# Altium schematic sheet AuxPowerSupply.SchDoc(AuxPowerSupply)
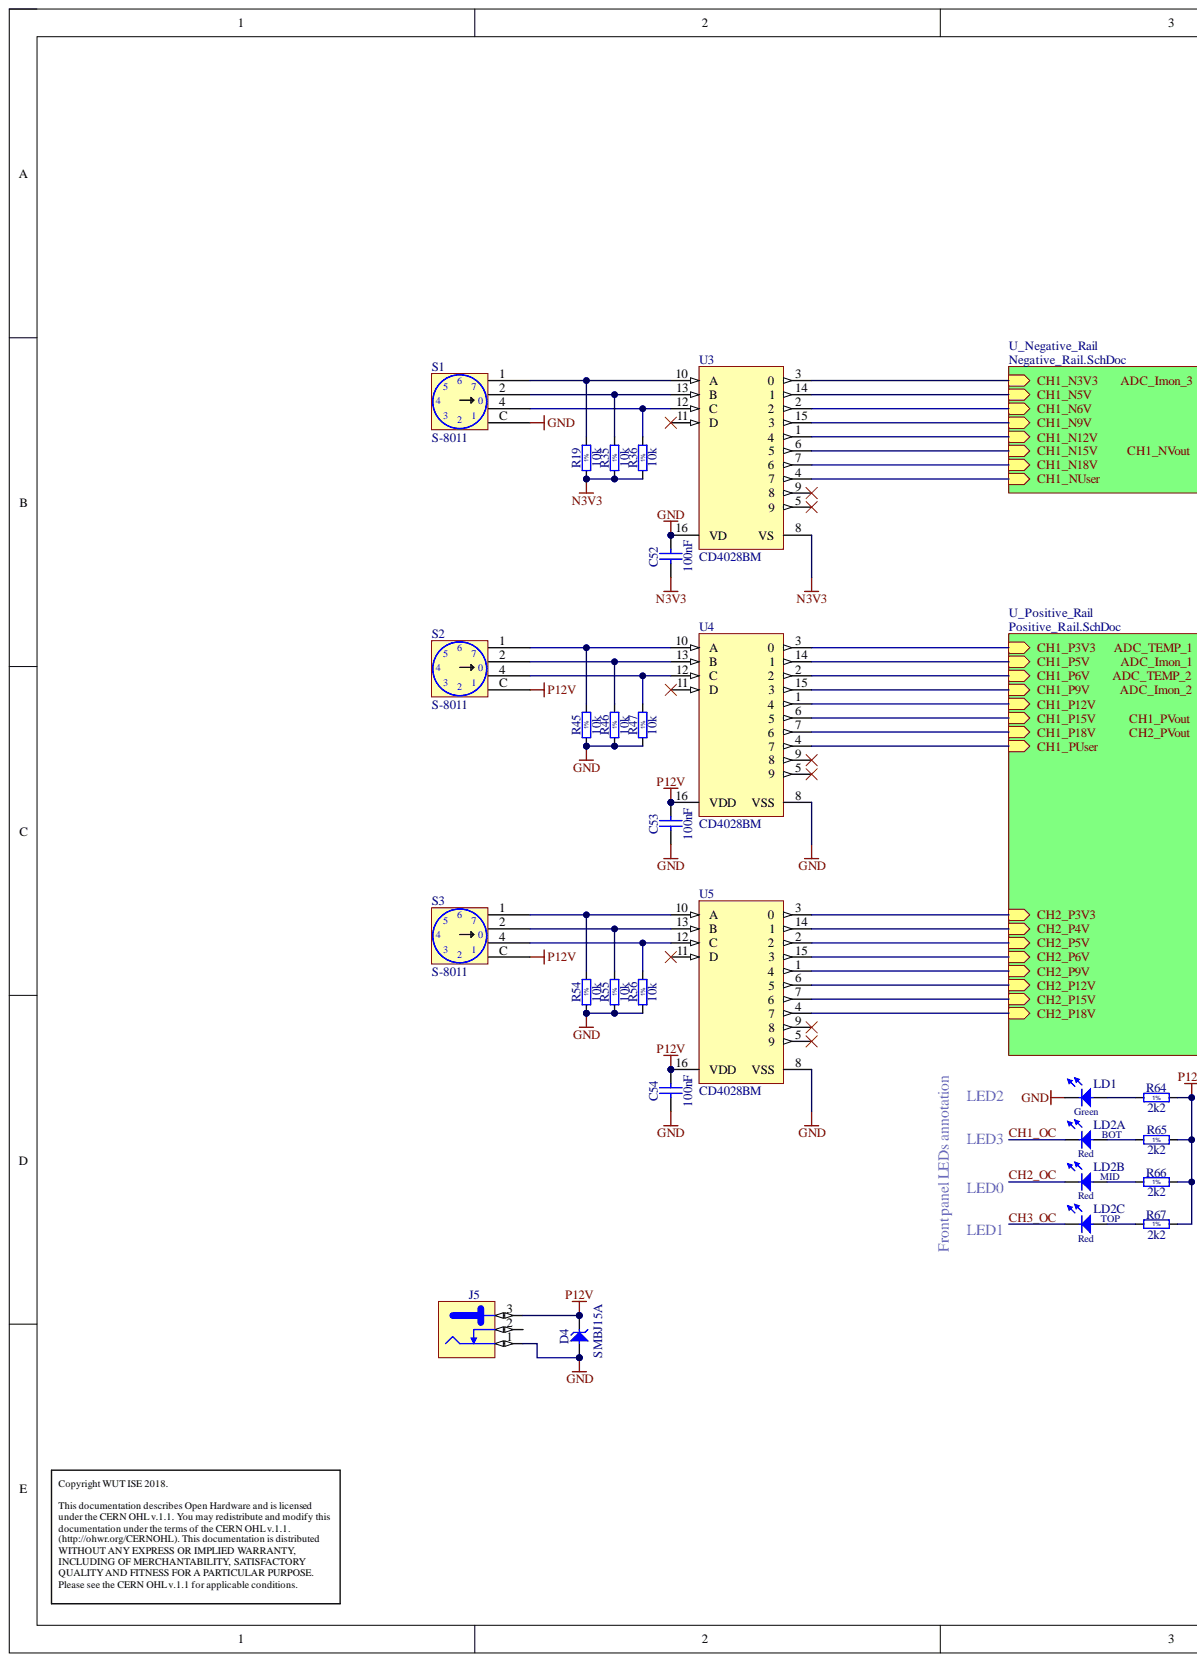
[diagram p0: AuxPowerSupply.SchDoc, left]
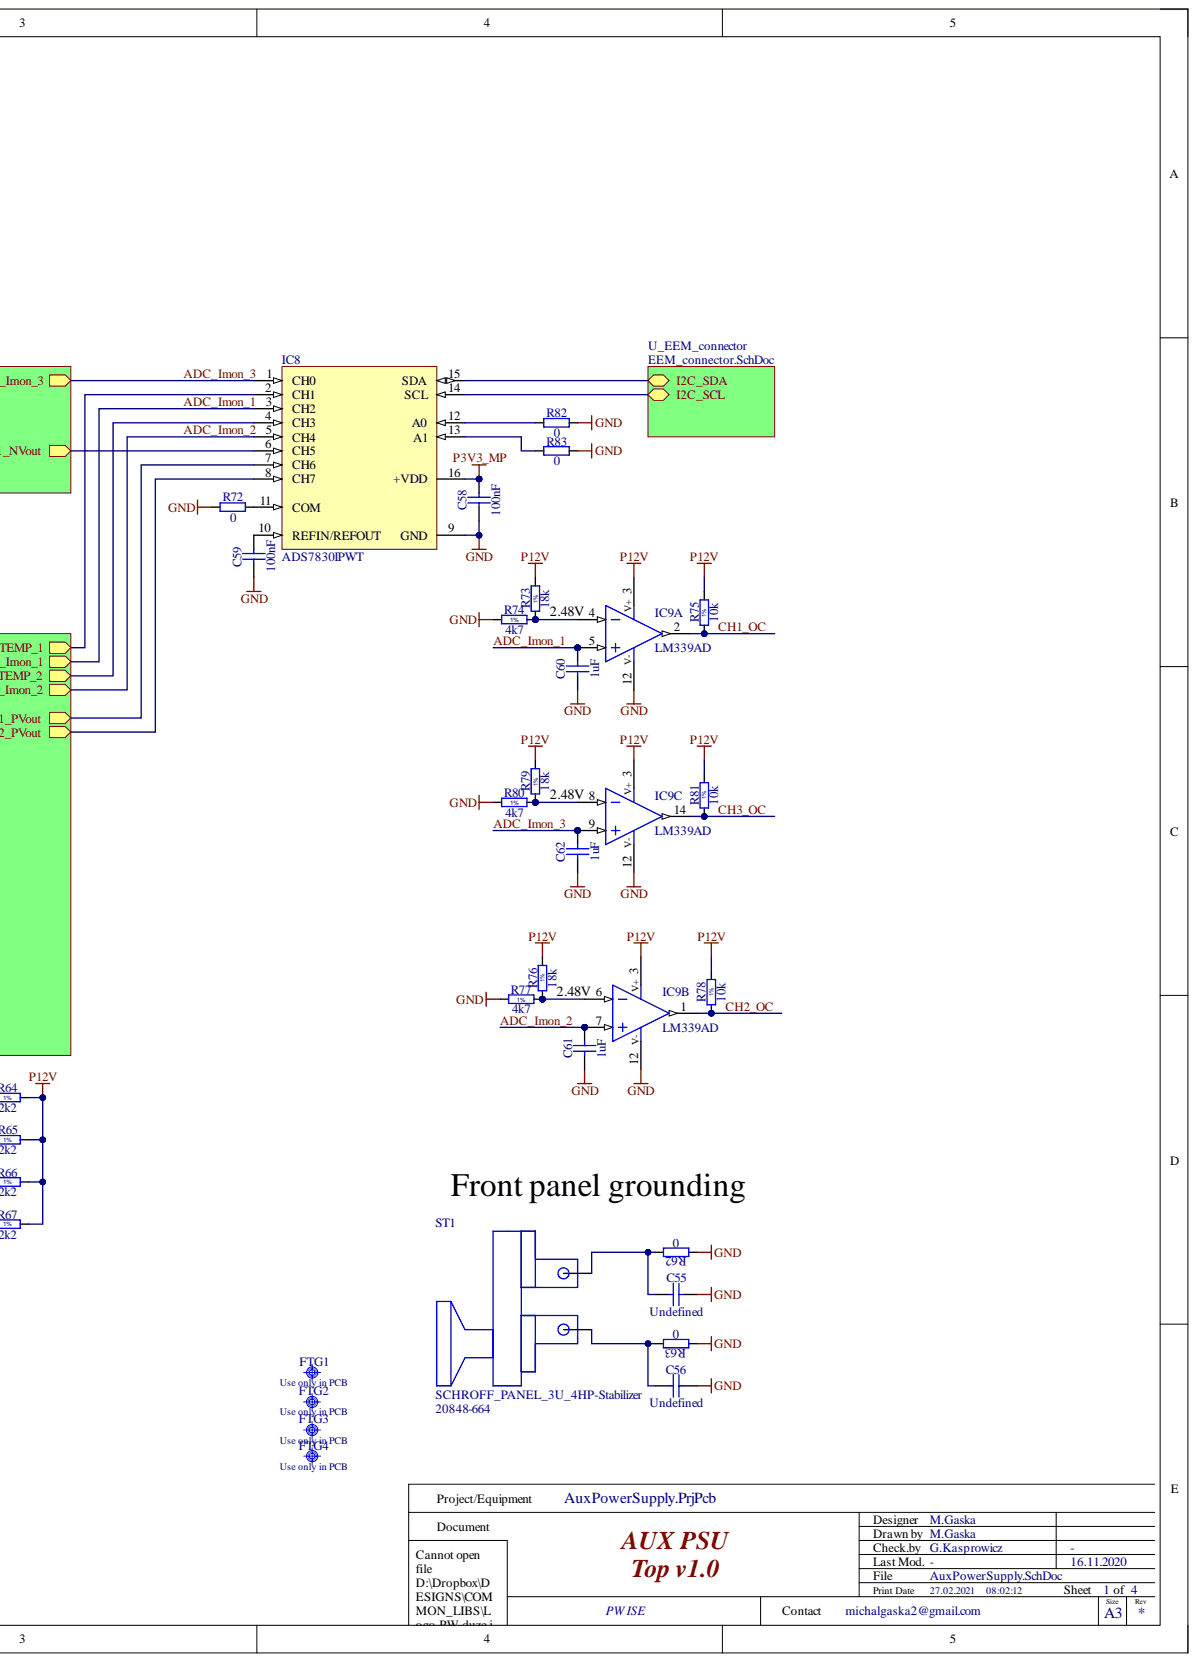
[diagram p1: AuxPowerSupply.SchDoc, right]

## Parts
C52  100nF  [CAPC1608X87N]
C53  100nF  [CAPC1608X87N]
C54  100nF  [CAPC1608X87N]
C55  Undefined  [CAPC2012X135N]
C56  Undefined  [CAPC2012X135N]
C58  100nF  [CAPC1608X87N]
C59  100nF  [CAPC1608X87N]
C60  1uF  [CAPC1608X87N]
C61  1uF  [CAPC1608X87N]
C62  1uF  [CAPC1608X87N]
D4  SMBJ15A  [DIOM5336X265N]
FTG1
FTG2
FTG3
FTG4
IC8  ADS7830IPWT  [SOP65P640X120-16N]
IC9  LM339AD  [SOIC127P600X175-14N]  (parts A,B,C)
J5  Jack  [SWITCHCRAFT_712RA]  (value not drawn)
LD1  Green  [LED_DIALIGHT_551-0207F]
LD2  Red/Red/Red  [LED_DIALIGHT_564-0100-111F]  (parts A,B,C)
R19  10k  [RESC1005X40N]
R35  10k  [RESC1005X40N]
R36  10k  [RESC1005X40N]
R45  10k  [RESC1005X40N]
R46  10k  [RESC1005X40N]
R47  10k  [RESC1005X40N]
R54  10k  [RESC1005X40N]
R55  10k  [RESC1005X40N]
R56  10k  [RESC1005X40N]
R62  0  [RESC1608X55N]
R63  0  [RESC1608X55N]
R64  2k2  [RESC1005X40N]
R65  2k2  [RESC1005X40N]
R66  2k2  [RESC1005X40N]
R67  2k2  [RESC1005X40N]
R72  0  [RESC1005X40N]
R73  18k  [RESC1005X40N]
R74  4k7  [RESC1005X40N]
R75  10k  [RESC1005X40N]
R76  18k  [RESC1005X40N]
R77  4k7  [RESC1005X40N]
R78  10k  [RESC1005X40N]
R79  18k  [RESC1005X40N]
R80  4k7  [RESC1005X40N]
R81  10k  [RESC1005X40N]
R82  0  [RESC1005X40N]
R83  0  [RESC1005X40N]
S1  S-8011  [S-8011]
S2  S-8011  [S-8011]
S3  S-8011  [S-8011]
ST1  SCHROFF_PANEL_3U_4HP-Stabilizer  Manufacturer Part Number 2: 20848-664  [SCHROFF_PANEL_3HP_4U - Stabilizer]
U3  CD4028BM  [D0016A_N]
U4  CD4028BM  [D0016A_N]
U5  CD4028BM  [D0016A_N]

## Nets
GND: C52.2 C53.1 C54.1 C55.2 C56.2 C58.1 C59.1 C60.1 C61.1 C62.1 D4.2 IC8.9(GND) IC9.12(V-) J5.1 LD1.1 R45.1 R46.1 R47.1 R54.1 R55.1 R56.1 R62.1 R63.1 R72.1 R74.1 R77.1 R80.1 R82.2 R83.2 S1.C U3.16(VD) U4.8(VSS) U5.8(VSS)
N3V3: C52.1 R19.1 R35.1 R36.1 U3.8(VS)
P3V3_MP: C58.2 IC8.16(+VDD)
P12V: C53.2 C54.2 D4.1 IC9.3 J5.3 R64.1 R65.1 R66.1 R67.1 R73.2 R75.2 R76.2 R78.2 R79.2 R81.2 S2.C S3.C U4.16(VDD) U5.16(VDD)
ADC_Imon_1: C60.2 IC8.3(CH2) IC9.5
ADC_Imon_2: C61.2 IC8.5(CH4) IC9.7
ADC_Imon_3: C62.2 IC8.1(CH0) IC9.9
CH1_OC: IC9.2 LD2.1 R75.1
CH2_OC: IC9.1 LD2.3 R78.1
CH3_OC: IC9.14 LD2.5 R81.1
NetC55_1: C55.1 R62.2 ST1.1
NetC56_1: C56.1 R63.2 ST1.0
NetC59_2: C59.2 IC8.10(REFIN/REFOUT)
NetIC8_2: IC8.2(CH1)
NetIC8_4: IC8.4(CH3)
NetIC8_6: IC8.6(CH5)
NetIC8_7: IC8.7(CH6)
NetIC8_8: IC8.8(CH7)
NetIC8_11: IC8.11(COM) R72.2
NetIC8_12: IC8.12(A0) R82.1
NetIC8_13: IC8.13(A1) R83.1
NetIC8_14: IC8.14(SCL)
NetIC8_15: IC8.15(SDA)
NetIC9_4: IC9.4 R73.1 R74.2
NetIC9_6: IC9.6 R76.1 R77.2
NetIC9_8: IC9.8 R79.1 R80.2
NetJ5_2: J5.2
NetLD1_2: LD1.2 R64.2
NetLD2_2: LD2.2 R65.2
NetLD2_4: LD2.4 R66.2
NetLD2_6: LD2.6 R67.2
NetR19_2: R19.2 S1.1 U3.10(A)
NetR35_2: R35.2 S1.2 U3.13(B)
NetR36_2: R36.2 S1.4 U3.12(C)
NetR45_2: R45.2 S2.1 U4.10(A)
NetR46_2: R46.2 S2.2 U4.13(B)
NetR47_2: R47.2 S2.4 U4.12(C)
NetR54_2: R54.2 S3.1 U5.10(A)
NetR55_2: R55.2 S3.2 U5.13(B)
NetR56_2: R56.2 S3.4 U5.12(C)
NetU3_1: U3.1
NetU3_2: U3.2
NetU3_3: U3.3
NetU3_4: U3.4
NetU3_5: U3.5
NetU3_6: U3.6
NetU3_7: U3.7
NetU3_9: U3.9
NetU3_11: U3.11(D)
NetU3_14: U3.14
NetU3_15: U3.15
NetU4_1: U4.1
NetU4_2: U4.2
NetU4_3: U4.3
NetU4_4: U4.4
NetU4_5: U4.5
NetU4_6: U4.6
NetU4_7: U4.7
NetU4_9: U4.9
NetU4_11: U4.11(D)
NetU4_14: U4.14
NetU4_15: U4.15
NetU5_1: U5.1
NetU5_2: U5.2
NetU5_3: U5.3
NetU5_4: U5.4
NetU5_5: U5.5
NetU5_6: U5.6
NetU5_7: U5.7
NetU5_9: U5.9
NetU5_11: U5.11(D)
NetU5_14: U5.14
NetU5_15: U5.15

## Library data of the parts
C52-C54 C58 C59 = CC0603_100NF_50V_10%_X5R: Non-polarised capacitor; Manufacturer: GENERIC; Manufacturer1 Part Number: C1608X5R1H104K080AA; Part Description: SMD Multilayer Chip Ceramic Capacitor; Database Table Name: Capacitors SMD; TC: X5R; Tolerance: ±10%; Voltage: 50V; Case: 0603; Component Kind: Standard; Component Type: Standard; CreateDate: 08.01.2016; HelpURL: \\cern.ch\dfs\Applications\Altium\Datasheets\CC0603_X5R_TDK_C.pdf; LatestRevisionDate: 08.01.2016; Library Name: Capacitors.DbLib; Manufacturer1 ComponentHeight: 0.9mm; Manufacturer1 Example: TDK; Manufacturer Part Number: CC0603_100NF_50V_10%_X5R; Mounted: Yes; Part Number: CC0603_100NF_50V_10%_X5R; Pin Count: 2; Sense: No; SMD: Yes; Socket: No; Status: None; Value: 100nF; Author: CERN DEM MR
C55 C56 = CC0805_NO-VALUE: Non-polarised capacitor; Part Description: Reserved Footprint For 0805(2012) Chip Ceramic Capacitor; Database Table Name: Capacitors SMD; Mounted: No; Case: 0805; Component Kind: Standard; Component Type: Standard; CreateDate: 10.12.2008; HelpURL: Undefined; LatestRevisionDate: 11.09.2013; Library Name: Capacitors.DbLib; Manufacturer: Undefined; Manufacturer1 ComponentHeight: 1.35mm; Manufacturer Part Number: CC0805_NO-VALUE; Part Number: CC0805_NO-VALUE; Pin Count: 2; Sense: No; SMD: Yes; Socket: No; Status: None; Value: Undefined; Author: CERN DEM JLC
C60-C62 = CC0603_1UF_25V_10%_X7R: Non-polarised capacitor; Manufacturer: GENERIC; Manufacturer1 Part Number: GRM188R71E105KA12D; Part Description: SMD Multilayer Chip Ceramic Capacitor; Database Table Name: Capacitors SMD; TC: X7R; Tolerance: ±10%; Voltage: 25V; Case: 0603; Component Kind: Standard; Component Type: Standard; CreateDate: 18.01.2011; HelpURL: \\cern.ch\dfs\Applications\Altium\Datasheets\CC0603_X7R_MURATA.pdf; LatestRevisionDate: 18.01.2011; Library Name: Capacitors.DbLib; Manufacturer1 ComponentHeight: 0.9mm; Manufacturer1 Example: MURATA; Manufacturer Part Number: CC0603_1UF_25V_10%_X7R; Mounted: Yes; Part Number: CC0603_1UF_25V_10%_X7R; Pin Count: 2; Sense: No; SMD: Yes; Socket: No; Status: None; Value: 1uF; Author: CERN DEM JLC
D4 = SMBJ15A: Zener Diode; Manufacturer: FAIRCHILD SEMICONDUCTOR; Part Description: Uni-Directional Transient Voltage Suppressor Diode; Bonding: No; Database Table Name: Diodes; Power: 600W; PressFit: No; Voltage: 15V; Case: DO-214AA; Component Kind: Standard; Component Type: Standard; ComponentHeight: 2.65mm; CreateDate: 08.12.2008; Device: SMBJ15A; HelpURL: \\cern.ch\dfs\Applications\Altium\Datasheets\SMBJ.pdf; LatestRevisionDate: 19.12.2011; Library Name: ICs And Semiconductors.DbLib; Manufacturer Part Number: SMBJ15A; Mounted: Yes; PackageDescription: DO-214-AA/SMB Diode, Molded Body; 5.34mm L X 3.625mm W X 2.65mm H, IPC Medium Density; Part Number: SMBJ15A; Pin Count: 2; Sense: No; SMD: Yes; Socket: No; Author: CERN DEM JLC
IC8 = ADS7830IPWT: 8-Bit, 8-Channel Sampling A/D Converter with I2C Interface 16-TSSOP -40 to 85; MF: Texas Instruments; Availability: Unavailable; Component Kind: Standard; MP: ADS7830IPWT; Package: TSSOP-16 Texas Instruments; Price: None; Library Name: MG_lib.SchLib
IC9 = LM339AD: Quad Operational Amplifier; Manufacturer: TEXAS INSTRUMENTS; Part Description: Quad Differential Comparator; Bonding: No; Database Table Name: Operational Amplifiers; PressFit: No; Case: SOIC14; Component Kind: Standard; Component Type: Standard; ComponentHeight: 1.75mm; CreateDate: 2011-03-09; Device: LM339AD; HelpURL: \\cern.ch\dfs\Applications\Altium\Datasheets\LM339AD.pdf; LatestRevisionDate: 2011-03-09; Library Name: ICs And Semiconductors.DbLib; Manufacturer Part Number: LM339AD; Mounted: Yes; PackageDescription: SOIC 14, Pitch 1.27mm - Body 4x8.75mm, IPC Medium Density; Part Number: LM339AD; Pin Count: 14; Sense: No; SMD: Yes; Socket: No; Author: CERN DEM JLC
J5 = (no symbol name): 24V /3. 5A, 2.1mm Diameter Pin, SMD DC Power Supply Connector With  Break Contact; Manufacturer: SWITCHCRAFT; Manufacturer Part Number: 712RA; Part Description: 12V/5A, 2.5mm Diameter Pin, THT DC Power Supply Connector With  Break Contact; PressFit: No; Component Kind: Standard; Component Type: Standard; ComponentHeight: 11mm; CreateDate: 15.02.2011; Family: Jack; HelpURL: \\cern.ch\dfs\Applications\Altium\Datasheets\LUMBERG_161314.pdf; LatestRevisionDate: 20.07.2011; Library Name: LIBRARY_SCH.SCHLIB; Mounted: Yes; Part Number: SWITCHCRAFT_712RA; Pin Count: 3; Sense: No; SMD: No; Socket: No; Status: None; Author: GK
LD1 = LED_DIALIGHT_551-0207F: LED Green 1C 2A; Part Description: Single 3mm Green LED CBI® Circuit Board Indicator, High Efficiency; Bonding: No; Database Table Name: LEDs & Displays; PressFit: No; Color: Green; Component Kind: Standard; Component Type: Standard; ComponentHeight: 7.11mm; CreateDate: 20.12.2017; HelpURL: \\cern.ch\dfs\Applications\Altium\Datasheets\LED_DIALIGHT_551-0207F.pdf; LatestRevisionDate: 20.12.2017; Library Name: ICs And Semiconductors.DbLib; Manufacturer: DIALIGHT; Manufacturer Part Number: 551-0207F; Mounted: Yes; PackageDescription: Single 3mm Green LED, Body 6.35x4.32mm, Pin spacing 2.54mm; Part Number: LED_DIALIGHT_551-0207F; Pin Count: 2; Sense: No; SMD: No; Socket: No; Author: CERN DEM MR
LD2 = LED_DIALIGHT_564-0100-111F: LED x3 Red LED; Part Description: Triple 3mm Red Diffused LEDs CBI®; Bonding: No; Database Table Name: LEDs & Displays; PressFit: No; Color: Red/Red/Red; Component Kind: Standard; Component Type: Standard; ComponentHeight: 15.24mm; CreateDate: 26.04.2010; HelpURL: \\cern.ch\dfs\Applications\Altium\Datasheets\LED_DIALIGHT_564-0100-111F.pdf; LatestRevisionDate: 26.04.2010; Library Name: ICs And Semiconductors.DbLib; Manufacturer: DIALIGHT; Manufacturer Part Number: 564-0100-111F; Mounted: Yes; PackageDescription: 3x Red LED 3mm PCB Edge Mounting DIALIGHT 564 Series; Part Number: LED_DIALIGHT_564-0100-111F; Pin Count: 6; Sense: No; SMD: No; Socket: No; Author: CERN DEM JLC
R19 R35 R36 R45-R47 R54-R56 R75 R78 R81 = R0402_10K_1%_0.0625W_100PPM: Resistor - 1%; Manufacturer: GENERIC; Manufacturer1 Part Number: 232270671003L; Part Description: General Purpose Thick Film Chip Resistor; Database Table Name: Resistors SMD; PressFit: No; TC: ±100ppm/°C; Case: 0402; Component Kind: Standard; Component Type: Standard; CreateDate: 03.12.2007; HelpURL: \\cern.ch\dfs\Applications\Altium\Datasheets\R0402_Phycomp_RC0402.pdf; LatestRevisionDate: 17.10.2012; Library Name: Resistors.DbLib; Manufacturer1 ComponentHeight: 0.4mm; Manufacturer1 Example: YAGEO PHYCOMP; Manufacturer Part Number: R0402_10K_1%_0.0625W_100PPM; Mounted: Yes; Part Number: R0402_10K_1%_0.0625W_100PPM; Pin Count: 2; Power: 0.0625W; Sense: No; SMD: Yes; Socket: No; Status: Not Recommended; Tolerance: ±1%; Value: 10k; Author: CERN DEM JLC
R62 R63 = R0603_0R_JUMPER: Resistor; Manufacturer: GENERIC; Manufacturer1 Part Number: NRC06Z0; Part Description: 1A (0.05R Max DC Resistance) Zero Ohm Jumper; Database Table Name: Resistors SMD; PressFit: No; Case: 0603; Component Kind: Standard; Component Type: Standard; CreateDate: 03.12.2007; HelpURL: \\cern.ch\dfs\Applications\Altium\Datasheets\R0603_NIC_NRC.pdf; LatestRevisionDate: 13.03.2008; Library Name: Resistors.DbLib; Manufacturer1 ComponentHeight: 0.55mm; Manufacturer1 Example: NIC COMPONENT; Manufacturer Part Number: R0603_0R_JUMPER; Mounted: Yes; Part Number: R0603_0R_JUMPER; Pin Count: 2; Sense: No; SMD: Yes; Socket: No; Status: Preferred; Value: 0; Author: CERN DEM JLC
R64-R67 = R0402_2K2_1%_0.0625W_100PPM: Resistor - 1%; Manufacturer: GENERIC; Manufacturer1 Part Number: 232270672202L; Part Description: General Purpose Thick Film Chip Resistor; Database Table Name: Resistors SMD; PressFit: No; TC: ±100ppm/°C; Case: 0402; Component Kind: Standard; Component Type: Standard; CreateDate: 03.12.2007; HelpURL: \\cern.ch\dfs\Applications\Altium\Datasheets\R0402_Phycomp_RC0402.pdf; LatestRevisionDate: 17.10.2012; Library Name: Resistors.DbLib; Manufacturer1 ComponentHeight: 0.4mm; Manufacturer1 Example: YAGEO PHYCOMP; Manufacturer Part Number: R0402_2K2_1%_0.0625W_100PPM; Mounted: Yes; Part Number: R0402_2K2_1%_0.0625W_100PPM; Pin Count: 2; Power: 0.0625W; Sense: No; SMD: Yes; Socket: No; Status: Not Recommended; Tolerance: ±1%; Value: 2k2; Author: CERN DEM JLC
R72 R82 R83 = R0402_0R_JUMPER: Resistor; Manufacturer: GENERIC; Manufacturer1 Part Number: 232270591001L; Part Description: 1A (0.05R Max DC Resistance) Zero Ohm Jumper; Database Table Name: Resistors SMD; PressFit: No; Case: 0402; Component Kind: Standard; Component Type: Standard; CreateDate: 2007-12-03; HelpURL: \\cern.ch\dfs\Applications\Altium\Datasheets\R0402_Phycomp_RC0402.pdf; LatestRevisionDate: 2012-10-17; Library Name: Resistors.DbLib; Manufacturer1 ComponentHeight: 0.4mm; Manufacturer1 Example: YAGEO PHYCOMP; Manufacturer Part Number: R0402_0R_JUMPER; Mounted: Yes; Part Number: R0402_0R_JUMPER; Pin Count: 2; Sense: No; SMD: Yes; Socket: No; Status: Not Recommended; Value: 0; Author: CERN DEM JLC
R73 R76 R79 = R0402_18K_1%_0.0625W_100PPM: Resistor - 1%; Manufacturer: GENERIC; Manufacturer1 Part Number: 232270671803L; Part Description: General Purpose Thick Film Chip Resistor; Database Table Name: Resistors SMD; PressFit: No; TC: ±100ppm/°C; Case: 0402; Component Kind: Standard; Component Type: Standard; CreateDate: 2007-12-03; HelpURL: \\cern.ch\dfs\Applications\Altium\Datasheets\R0402_Phycomp_RC0402.pdf; LatestRevisionDate: 2012-10-17; Library Name: Resistors.DbLib; Manufacturer1 ComponentHeight: 0.4mm; Manufacturer1 Example: YAGEO PHYCOMP; Manufacturer Part Number: R0402_18K_1%_0.0625W_100PPM; Mounted: Yes; Part Number: R0402_18K_1%_0.0625W_100PPM; Pin Count: 2; Power: 0.0625W; Sense: No; SMD: Yes; Socket: No; Status: Not Recommended; Tolerance: ±1%; Value: 18k; Author: CERN DEM JLC
R74 R77 R80 = R0402_4K7_1%_0.0625W_100PPM: Resistor - 1%; Manufacturer: GENERIC; Manufacturer1 Part Number: 232270674702L; Part Description: General Purpose Thick Film Chip Resistor; Database Table Name: Resistors SMD; PressFit: No; TC: ±100ppm/°C; Case: 0402; Component Kind: Standard; Component Type: Standard; CreateDate: 2007-12-03; HelpURL: \\cern.ch\dfs\Applications\Altium\Datasheets\R0402_Phycomp_RC0402.pdf; LatestRevisionDate: 2012-10-17; Library Name: Resistors.DbLib; Manufacturer1 ComponentHeight: 0.4mm; Manufacturer1 Example: YAGEO PHYCOMP; Manufacturer Part Number: R0402_4K7_1%_0.0625W_100PPM; Mounted: Yes; Part Number: R0402_4K7_1%_0.0625W_100PPM; Pin Count: 2; Power: 0.0625W; Sense: No; SMD: Yes; Socket: No; Status: Not Recommended; Tolerance: ±1%; Value: 4k7; Author: CERN DEM JLC
ST1 = SCHROFF_PANEL_3U_4HP_Stabilizer: Schroff 3HP 4U EUROCARD Panel; Manufacturer Part Number: 20818-017; Component Kind: Standard; Library Name: Mechanical.SchLib; Manufacturer: Schroff
U3-U5 = CMP-1633-00055-1: CMOS BCD-to-Decimal or Binary-to-Octal Decoders/Drivers, D0016A, TUBE; Manufacturer: Texas Instruments; Bits(#): 10; Channels(#): 1; Configuration: 4：10; F @ Nom Voltage(Max)(Mhz): 8; Function: Decoder/Demultiplexer; ICC @ Nom Voltage(Max)(mA): 0.3; Output Drive (IOL/IOH)(Max)(mA): 1.5/-1.5; Rating: Catalog; Schmitt Trigger: No; Technology Family: CD4000; tpd @ Nom Voltage(Max)(ns): 160; VCC(Max)(V): 18; VCC(Min)(V): 3; Voltage(Nom)(V): 5,10,15; Code_JEDEC: MS-012 variation AC; Component Kind: Standard; ComponentLink1Description: Manufacturer URL; ComponentLink1URL: http://www.ti.com/; ComponentLink2Description: Datasheet; ComponentLink2URL: http://www.ti.com/lit/ds/symlink/cd4028b.pdf; ComponentLink3Description: Package Specification; ComponentLink3URL: http://www.ti.com/lit/ml/mpds178g/mpds178g.pdf; DatasheetVersion: SCHS033C; Generic Part Number: CD4028B; Input Type: CMOS; Library Name: Altium Content Vault; Operating Temperature Range(C): -55 to 125; Output Type: CMOS; PackageReference: D0016A; PartNumber: CD4028BM; Type: Standard
S1-S3 = S-8011: Component Kind: Standard; Library Name: MG_lib.SchLib
